# Altium schematic sheet Z80 Card for Commodore C64.SchDoc("Z80 Card for Commodore C64")
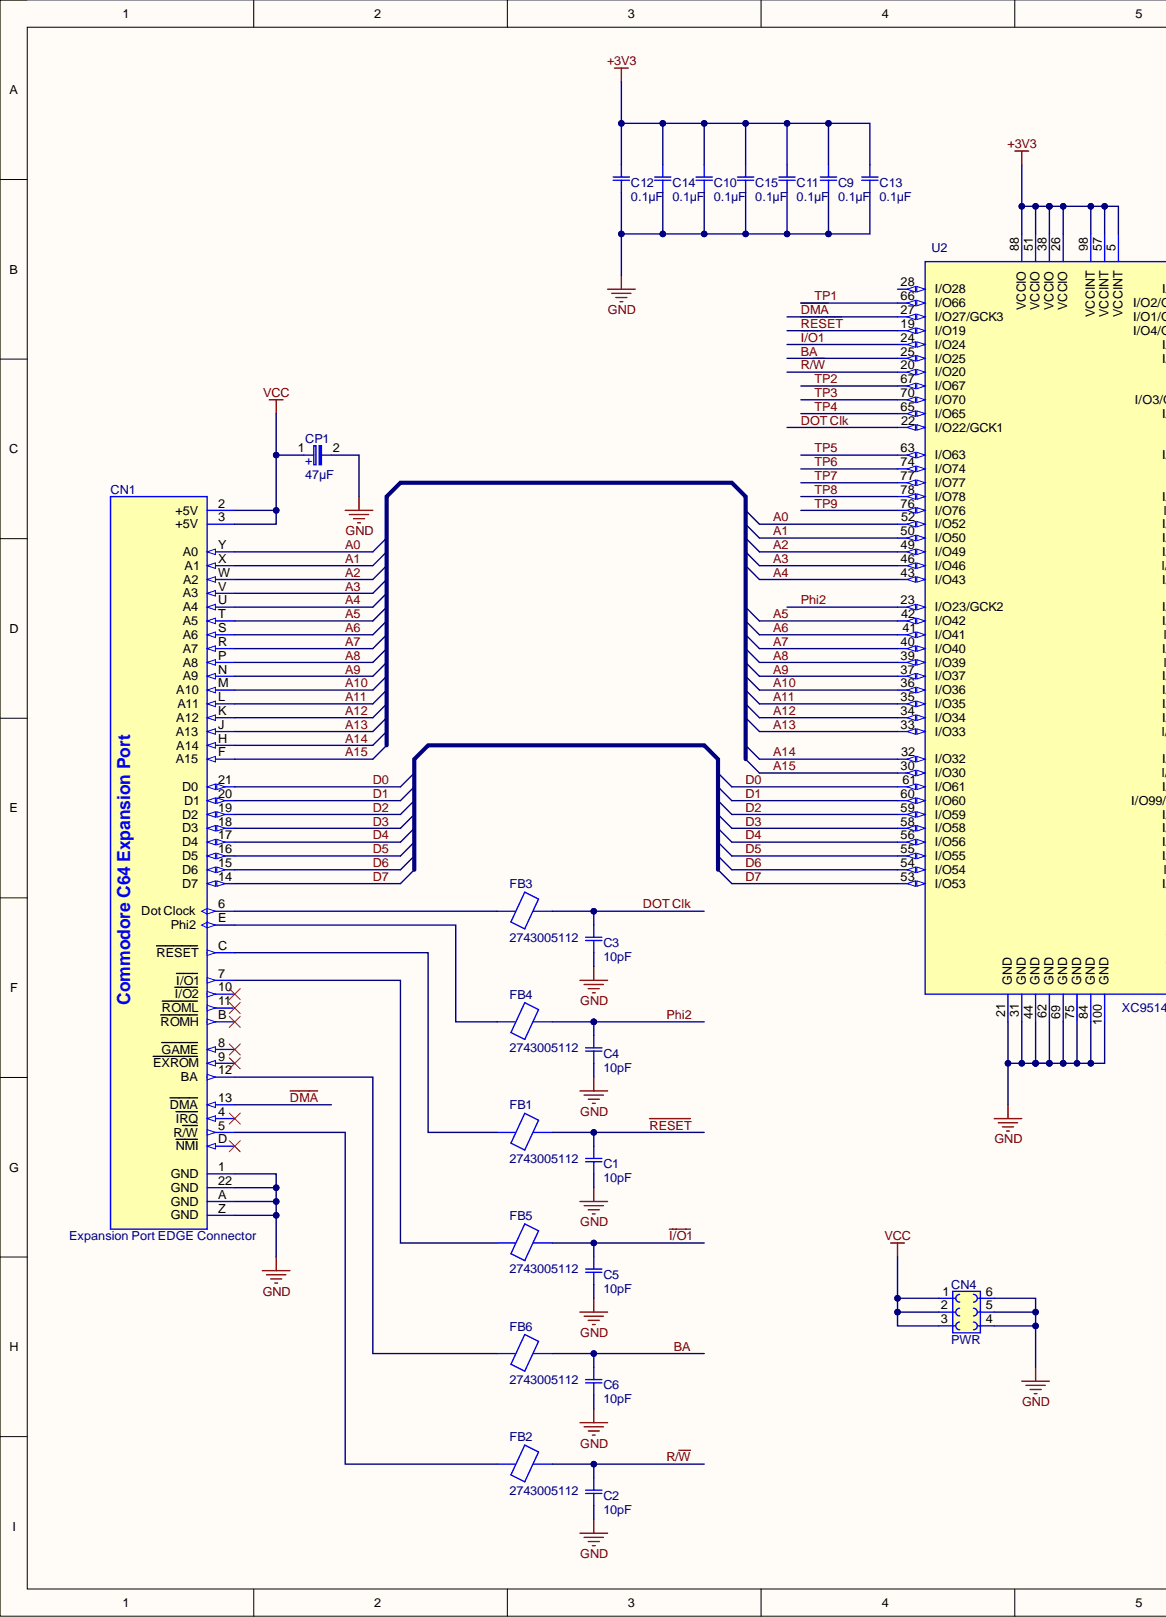
[diagram p0: Z80 Card for Commodore C64.SchDoc, left]
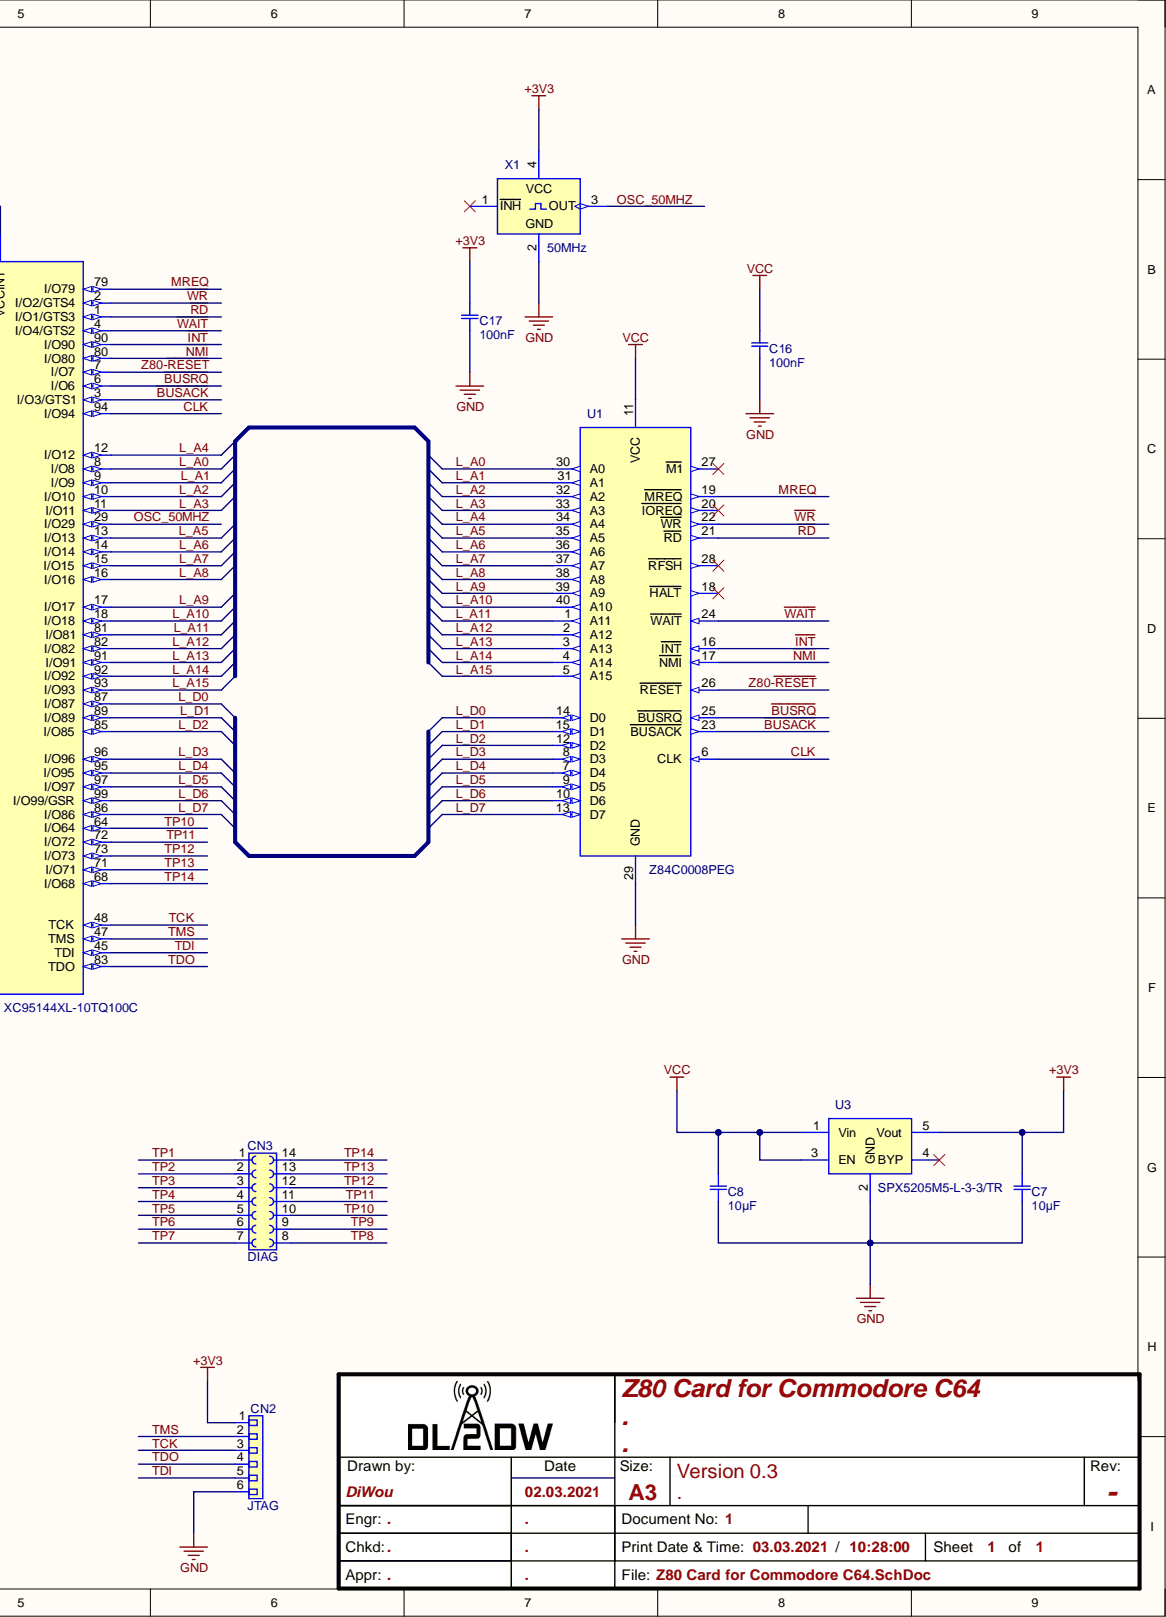
[diagram p1: Z80 Card for Commodore C64.SchDoc, right]

## Parts
C1  10pF  [CAP CER 10PF 25V C0G-NP0 RADIAL Kemet C315C100J3G5TA]
C2  10pF  [CAP CER 10PF 25V C0G-NP0 RADIAL Kemet C315C100J3G5TA]
C3  10pF  [CAP CER 10PF 25V C0G-NP0 RADIAL Kemet C315C100J3G5TA]
C4  10pF  [CAP CER 10PF 25V C0G-NP0 RADIAL Kemet C315C100J3G5TA]
C5  10pF  [CAP CER 10PF 25V C0G-NP0 RADIAL Kemet C315C100J3G5TA]
C6  10pF  [CAP CER 10PF 25V C0G-NP0 RADIAL Kemet C315C100J3G5TA]
C7  10µF  [Kemet C0805CxxxK8RACTU Series H=1.4mm]
C8  10µF  [Kemet C0805CxxxK8RACTU Series H=1.4mm]
C9  0.1µF  [CAP 100nF SMD 0603 C0603C104J3RACTU]
C10  0.1µF  [CAP 100nF SMD 0603 C0603C104J3RACTU]
C11  0.1µF  [CAP 100nF SMD 0603 C0603C104J3RACTU]
C12  0.1µF  [CAP 100nF SMD 0603 C0603C104J3RACTU]
C13  0.1µF  [CAP 100nF SMD 0603 C0603C104J3RACTU]
C14  0.1µF  [CAP 100nF SMD 0603 C0603C104J3RACTU]
C15  0.1µF  [CAP 100nF SMD 0603 C0603C104J3RACTU]
C16  100nF  [CAP Disc 100nF P2.54mm D5.5mm T3mm]
C17  100nF  [CAP 100nF SMD 0603 C0603C104J3RACTU]
CN1  Expansion Port EDGE Connector  [C64 Expansion Port EDGE Connector]  (no BOM)
CN2  JTAG  [Pin Header 01x06 2.54mm Horizontal Male]
CN3  DIAG  [Female Pin Header 02x07 Straight P2.54mm H8.5mm]
CN4  PWR  [Female Pin Header 02x03 Straight P2.54mm H8.5mm]
CP1  47µF  [CAP 47µF16V D6mm H6.3mm P2.5mm]
FB1  2743005112  [Ferrite Bead Fair Rite 2743005112]
FB2  2743005112  [Ferrite Bead Fair Rite 2743005112]
FB3  2743005112  [Ferrite Bead Fair Rite 2743005112]
FB4  2743005112  [Ferrite Bead Fair Rite 2743005112]
FB5  2743005112  [Ferrite Bead Fair Rite 2743005112]
FB6  2743005112  [Ferrite Bead Fair Rite 2743005112]
U1  Z84C0008PEG  [Zilog Z80 CPU with Socket]
U2  XC95144XL-10TQ100C  [TQFP-100 P0.5mm XC95144XL-7TQ100C]
U3  SPX5205M5-L-3-3/TR  [SOT-23-5]
X1  50MHz  [50.000MHZ Oscilator EuroQuartz XO53050UITA]

## Nets
+3V3: C7.2 C9.2 C10.2 C11.2 C12.2 C13.2 C14.2 C15.2 C17.2 CN2.1 U2.5(VCCINT) U2.26(VCCIO) U2.38(VCCIO) U2.51(VCCIO) U2.57(VCCINT) U2.88(VCCIO) U2.98(VCCINT) U3.5(Vout) X1.4
GND: C1.1 C2.1 C3.1 C4.1 C5.1 C6.1 C7.1 C8.1 C9.1 C10.1 C11.1 C12.1 C13.1 C14.1 C15.1 C16.1 C17.1 CN1.1(GND) CN1.22(GND) CN1.A(GND) CN1.Z(GND) CN2.6 CN4.4 CN4.5 CN4.6 CP1.2 U1.29(GND) U2.21(GND) U2.31(GND) U2.44(GND) U2.62(GND) U2.69(GND) U2.75(GND) U2.84(GND) U2.100(GND) U3.2(GND) X1.2
VCC: C8.2 C16.2 CN1.2(+5V) CN1.3(+5V) CN4.1 CN4.2 CN4.3 CP1.1 U1.11(VCC) U3.1(Vin) U3.3(EN)
A0: CN1.Y(A0) U2.52(I/O52)
A1: CN1.X(A1) U2.50(I/O50)
A2: CN1.W(A2) U2.49(I/O49)
A3: CN1.V(A3) U2.46(I/O46)
A4: CN1.U(A4) U2.43(I/O43)
A5: CN1.T(A5) U2.42(I/O42)
A6: CN1.S(A6) U2.41(I/O41)
A7: CN1.R(A7) U2.40(I/O40)
A8: CN1.P(A8) U2.39(I/O39)
A9: CN1.N(A9) U2.37(I/O37)
A10: CN1.M(A10) U2.36(I/O36)
A11: CN1.L(A11) U2.35(I/O35)
A12: CN1.K(A12) U2.34(I/O34)
A13: CN1.J(A13) U2.33(I/O33)
A14: CN1.H(A14) U2.32(I/O32)
A15: CN1.F(A15) U2.30(I/O30)
~{BUSACK}: U1.23(BUSACK) U2.3(I/O3/GTS1)
~{BUSRQ}: U1.25(BUSRQ) U2.6(I/O6)
BA: C6.2 FB6.2 U2.25(I/O25)
CLK: U1.6(CLK) U2.94(I/O94)
D0: CN1.21(D0) U2.61(I/O61)
D1: CN1.20(D1) U2.60(I/O60)
D2: CN1.19(D2) U2.59(I/O59)
D3: CN1.18(D3) U2.58(I/O58)
D4: CN1.17(D4) U2.56(I/O56)
D5: CN1.16(D5) U2.55(I/O55)
D6: CN1.15(D6) U2.54(I/O54)
D7: CN1.14(D7) U2.53(I/O53)
~{DMA}: CN1.13(DMA) U2.27(I/O27/GCK3)
DOT Clk: C3.2 FB3.2 U2.22(I/O22/GCK1)
~{I/O1}: C5.2 FB5.2 U2.24(I/O24)
~{INT}: U1.16(INT) U2.90(I/O90)
L_A0: U1.30(A0) U2.8(I/O8)
L_A1: U1.31(A1) U2.9(I/O9)
L_A2: U1.32(A2) U2.10(I/O10)
L_A3: U1.33(A3) U2.11(I/O11)
L_A4: U1.34(A4) U2.12(I/O12)
L_A5: U1.35(A5) U2.13(I/O13)
L_A6: U1.36(A6) U2.14(I/O14)
L_A7: U1.37(A7) U2.15(I/O15)
L_A8: U1.38(A8) U2.16(I/O16)
L_A9: U1.39(A9) U2.17(I/O17)
L_A10: U1.40(A10) U2.18(I/O18)
L_A11: U1.1(A11) U2.81(I/O81)
L_A12: U1.2(A12) U2.82(I/O82)
L_A13: U1.3(A13) U2.91(I/O91)
L_A14: U1.4(A14) U2.92(I/O92)
L_A15: U1.5(A15) U2.93(I/O93)
L_D0: U1.14(D0) U2.87(I/O87)
L_D1: U1.15(D1) U2.89(I/O89)
L_D2: U1.12(D2) U2.85(I/O85)
L_D3: U1.8(D3) U2.96(I/O96)
L_D4: U1.7(D4) U2.95(I/O95)
L_D5: U1.9(D5) U2.97(I/O97)
L_D6: U1.10(D6) U2.99(I/O99/GSR)
L_D7: U1.13(D7) U2.86(I/O86)
MREQ: U1.19(MREQ) U2.79(I/O79)
~{NMI}: U1.17(NMI) U2.80(I/O80)
OSC_50MHZ: U2.29(I/O29) X1.3(OUT)
Phi2: C4.2 FB4.2 U2.23(I/O23/GCK2)
R/~{W}: C2.2 FB2.2 U2.20(I/O20)
~{RD}: U1.21(RD) U2.1(I/O1/GTS3)
~{RESET}: C1.2 FB1.2 U2.19(I/O19)
TCK: CN2.3 U2.48(TCK)
TDI: CN2.5 U2.45(TDI)
TDO: CN2.4 U2.83(TDO)
TMS: CN2.2 U2.47(TMS)
TP1: CN3.1 U2.66(I/O66)
TP2: CN3.2 U2.67(I/O67)
TP3: CN3.3 U2.70(I/O70)
TP4: CN3.4 U2.65(I/O65)
TP5: CN3.5 U2.63(I/O63)
TP6: CN3.6 U2.74(I/O74)
TP7: CN3.7 U2.77(I/O77)
TP8: CN3.8 U2.78(I/O78)
TP9: CN3.9 U2.76(I/O76)
TP10: CN3.10 U2.64(I/O64)
TP11: CN3.11 U2.72(I/O72)
TP12: CN3.12 U2.73(I/O73)
TP13: CN3.13 U2.71(I/O71)
TP14: CN3.14 U2.68(I/O68)
~{WAIT}: U1.24(WAIT) U2.4(I/O4/GTS2)
~{WR}: U1.22(WR) U2.2(I/O2/GTS4)
Z80-~{RESET}: U1.26(RESET) U2.7(I/O7)
NetCN1_5: CN1.5(R/W) FB2.1
NetCN1_6: CN1.6(Dot_Clock) FB3.1
NetCN1_7: CN1.7(I/O1) FB5.1
NetCN1_12: CN1.12(BA) FB6.1
NetCN1_C: CN1.C(RESET) FB1.1
NetCN1_E: CN1.E(Phi2) FB4.1

Not connected: CN1 4(IRQ) 8(GAME) 9(EXROM) 10(I/O2) 11(ROML) B(ROMH) D(NMI); U1 18(HALT) 20(IOREQ) 27(M1) 28(RFSH); U2 28(I/O28); U3 4(BYP); X1 1(INH)

## Library data of the parts
C1-C6 = CMP-Capacitors-Ceramic_Capacitors-00049-1: CAP CER 10PF 25V C0G/NP0 RADIAL; Component Kind: Standard; Library Name: PCB-Tech Cloud
C7 C8 = CMP-Capacitors-Ceramic_Capacitors-00016-2: KEMET - C0805C106K8RACTU - CAP, 10µF, 10V, 10%, X7R, 0805; Capacitance: 10uF; Dissipation Factor: 7.5%; Case Code (Imperial): 0805; Case Code (Metric): 2012; Case/Package: 0805; Component Kind: Standard; Depth: 1.25mm; Dielectric: X7R; Dielectric Material: Ceramic; Height: 1.25mm; Length: 2mm; Library Name: PCB-Tech Cloud; Max Operating Temperature: 125°C; Min Operating Temperature: -55°C; Mount: Surface Mount,PCB; Package Quantity: 1; Packaging: Tape and Reel; REACH SVHC: No SVHC; Termination: SMD/SMT; Thickness: 0.055inch; Tolerance: 10%; Voltage: 10V; Voltage Rating: 10V; Voltage Rating (DC): 10V; Width: 1.2mm
C9-C15 = CMP-Capacitors-Ceramic_Capacitors-00012-1: KEMET         C0603C104J3RACTU             SMD Multilayer Ceramic Capacitor, 0603 [1608 Metric], 0.1 F, 25 V,  5%, X7R, C Series; Capacitance: 100nF; Voltage: 25V; Case Code (Imperial): 0603; Case Code (Metric): 1608; Case/Package: 0603; Component Kind: Standard; Contact Plating: Tin,Matte; Depth: 0.8mm; Dielectric: X7R; Dielectric Material: Ceramic; Height: 0.8mm; Lead Pitch: 0.7mm; Length: 1.6mm; Library Name: PCB-Tech Cloud; Max Operating Temperature: 125°C; Min Operating Temperature: -55°C; Mount: Surface Mount,PCB; Number of Pins: 2; Package Quantity: 4000; Packaging: Tape and Reel; Radiation Hardening: No; REACH SVHC: No SVHC; Termination: SMD/SMT; Thickness: 0.034inch; Tolerance: 5%; Voltage Rating: 25V; Voltage Rating (DC): 25V; Width: 0.031inch
C16 = CMP-Capacitors-Ceramic_Capacitors-00007-4: Ceramic Disc Capacitors 100nF -20%~+80% 50V Through Hole,P=2.54mm RoHS; Component Kind: Standard; ComponentLink1URL: https://lcsc.com/product-detail/Others_Dersonic-CC1H104ZA1PD3F5O3000_C353909.html; Library Name: PCB-Tech Cloud
C17 = CMP-Capacitors-Ceramic_Capacitors-00012-1: KEMET         C0603C104J3RACTU             SMD Multilayer Ceramic Capacitor, 0603 [1608 Metric], 0.1 F, 25 V,  5%, X7R, C Series; Voltage: 25V; Capacitance: 100nF; Case Code (Imperial): 0603; Case Code (Metric): 1608; Case/Package: 0603; Component Kind: Standard; Contact Plating: Tin,Matte; Depth: 0.8mm; Dielectric: X7R; Dielectric Material: Ceramic; Height: 0.8mm; Lead Pitch: 0.7mm; Length: 1.6mm; Library Name: PCB-Tech Cloud; Max Operating Temperature: 125°C; Min Operating Temperature: -55°C; Mount: Surface Mount,PCB; Number of Pins: 2; Package Quantity: 4000; Packaging: Tape and Reel; Radiation Hardening: No; REACH SVHC: No SVHC; Termination: SMD/SMT; Thickness: 0.034inch; Tolerance: 5%; Voltage Rating: 25V; Voltage Rating (DC): 25V; Width: 0.031inch
CN1 = CMP-Commodore-00008-4: Expansion Port EDGE Connector for Interface Cards; Library Name: PCB-Tech Cloud
CN2 = CMP-Connectors-Male_PIN_Headers-00005-2: CONN HEADER VERT 6POS 2.54MM; Connector Type: Male Pin; Number of Positions: 6; Pitch: 0.1inch; Color: Black; Component Kind: Standard; Contact Mating Length: 0.318inch; Contact Plating: Gold; Library Name: PCB-Tech Cloud; Mount: Through Hole; Number of Rows: 1; Package Quantity: 1; Packaging: Bulk; Termination: Solder
CN3 = CMP-Connectors-Female_Pin_Headers00044-1: Headers & Wire Housings 7+7 DIL VERT SOCKET; Connector Type: Receptacle,Socket; Contact Resistance: 20mR; Gender: Female; Max Current Rating: 3A; Number of Contacts: 14; Pitch: 2.54mm; Row Spacing: 0.1inch; Color: Black; Component Kind: Standard; Contact Gender: Female; Contact Material: Bronze; Contact Plating: Tin,Gold; Depth: 5.04mm; Housing Color: Black; Housing Material: Polyamide; Length: 18.18mm; Library Name: PCB-Tech Cloud; Max Operating Temperature: 105°C; Min Operating Temperature: -40°C; Mount: Through Hole; Number of Positions: 14; Number of Rows: 2; Orientation: Straight; Package Quantity: 33; Packaging: Rail/Tube; Radiation Hardening: No; Termination: Solder
CN4 = CMP-Connectors-Female_Pin_Headers00040-1: Headers & Wire Housings 03+03 DIL VERTICAL SOCKET GOLD+TIN; Connector Type: Receptacle,Socket; Contact Resistance: 20mR; Gender: Female; Max Current Rating: 3A; Max Voltage Rating (AC): 500V; Number of Contacts: 6; Pitch: 2.54mm; Row Spacing: 0.1inch; Color: Black; Component Kind: Standard; Contact Gender: Female; Contact Material: Bronze; Contact Plating: Gold,Tin; Depth: 5mm; Housing Color: Black; Housing Material: Nylon; Length: 8.02mm; Library Name: PCB-Tech Cloud; Max Operating Temperature: 105°C; Min Operating Temperature: -40°C; Mount: Through Hole; Number of Positions: 6; Number of Rows: 2; Orientation: Straight; Package Quantity: 74; Packaging: Rail/Tube; Radiation Hardening: No; Termination: Solder
CP1 = CMP-Capacitors-Electrolyt_Capacitors00000-5: Aluminum Electrolytic Capacitors - Leaded 47uF 105c; Impedance: 1.3R; Life (Hours): 1000Hour; Ripple Current: 115mA; Tolerance: 20%; Voltage Rating: 16V; Capacitance: 47uF; Case/Package: Radial; Component Kind: Standard; Height - Seated (Max): 0.236inch; Lead Pitch: 0.098inch; Length: 5mm; Library Name: PCB-Tech Cloud; Max Operating Temperature: 105°C; Min Operating Temperature: -55°C; Mount: Through Hole; Package Quantity: 2000; Packaging: Tape and Reel; Termination: Radial; Voltage Rating (DC): 16V
FB1-FB6 = CMP-Inductors-00000-10: Ferrite Beads Axial 91Ohm 100MHz T/R; Impedance: 91R; Shielding: Unshielded; Case/Package: Axial; Component Kind: Standard; Diameter: 3.5mm; Length: 6mm; Library Name: PCB-Tech Cloud; Max Operating Temperature: 125°C; Min Operating Temperature: -55°C; Package Quantity: 4500; Packaging: Tape and Reel; Termination: Axial; Test Frequency: 100MHz
U1 = CMP-ICs-00009-1: IC MPU Z80 8MHZ 40DIP; Core Architecture: Z8; Data Bus Width: 8b; Max Supply Voltage: 5V; Memory Size: 64KB; Memory Type: ROMless; Min Supply Voltage: 4.5V; Number of I/Os: 24; Case/Package: DIP; Component Kind: Standard; Frequency: 8MHz; Height: 4.19mm; Lead Free: Lead Free; Length: 62.74mm; Library Name: PCB-Tech Cloud; Max Frequency: 8MHz; Max Operating Temperature: 100°C; Min Operating Temperature: -40°C; Mount: Through Hole; Number of Pins: 40; Package Quantity: 10; Packaging: Rail/Tube; Radiation Hardening: No; REACH SVHC: Unknown; Voltage: 5V; Width: 14.22mm
U2 = CMP-ICs-CPLD_FPGA-00000-1: IC CPLD 144MC 7.5NS 100TQFP; Max Frequency: 125MHz; Max Supply Voltage: 3.6V; Memory Type: FLASH; Min Supply Voltage: 3V; Number of Gates: 3200; Number of I/Os: 81; Number of Logic Blocks (LABs): 8; Number of Macrocells: 144; Operating Supply Voltage: 3.3V; Speed Grade: 7; Case/Package: LQFP; Component Kind: Standard; Contact Plating: Tin,Lead; Lead Free: Contains Lead; Library Name: PCB-Tech Cloud; Max Operating Temperature: 70°C; Min Operating Temperature: 0°C; Mount: Surface Mount; Number of Logic Elements/Cells: 8; Number of Pins: 100; Package Quantity: 90; Packaging: Tray; Propagation Delay: 7.5ns; Turn-On Delay Time: 7.5ns
U3 = CMP-ICs-Power_Supply-00002-2: LDO Regulator Pos 3.3V 0.15A 5-Pin SOT-23 T/R; Accuracy: 1%; Dropout Voltage: 210mV; Max Input Voltage: 16V; Max Output Current: 150mA; Min Input Voltage: 2.5V; Number of Outputs: 1; Output Type: Fixed; Polarity: Positive; Quiescent Current: 1uA; Case/Package: SOT-23; Component Kind: Standard; Height: 1.15mm; Lead Free: Lead Free; Length: 2.9mm; Library Name: PCB-Tech Cloud; Max Operating Temperature: 125°C; Min Operating Temperature: -40°C; Min Output Voltage: 3.3V; Mount: Surface Mount; Number of Pins: 5; Number of Regulators: 1; Output Current: 150mA; Output Voltage: 3.3V; Packaging: Tape and Reel; Radiation Hardening: No; Width: 1.6mm
X1 = CMP-Crystals_Oscilators-00004-1: XO53050UITA-50.000, Crystal Oscillator, 50 MHz, 50ppm HCMOS, LSTTL 15pF, 4-Pin SMD, 5 x 3.2 x 1mm; Case/Package: SMD/SMT; Component Kind: Standard; Frequency Stability: 50ppm; Height: 1mm; Length: 5mm; Library Name: PCB-Tech Cloud; Load Capacitance: 15pF; Max Operating Temperature: 85°C; Min Operating Temperature: -40°C; Mount: Surface Mount; Number of Pins: 4; Width: 3.2mm
